# Altium schematic sheet [05] Charger.SchDoc("[05] Charger")
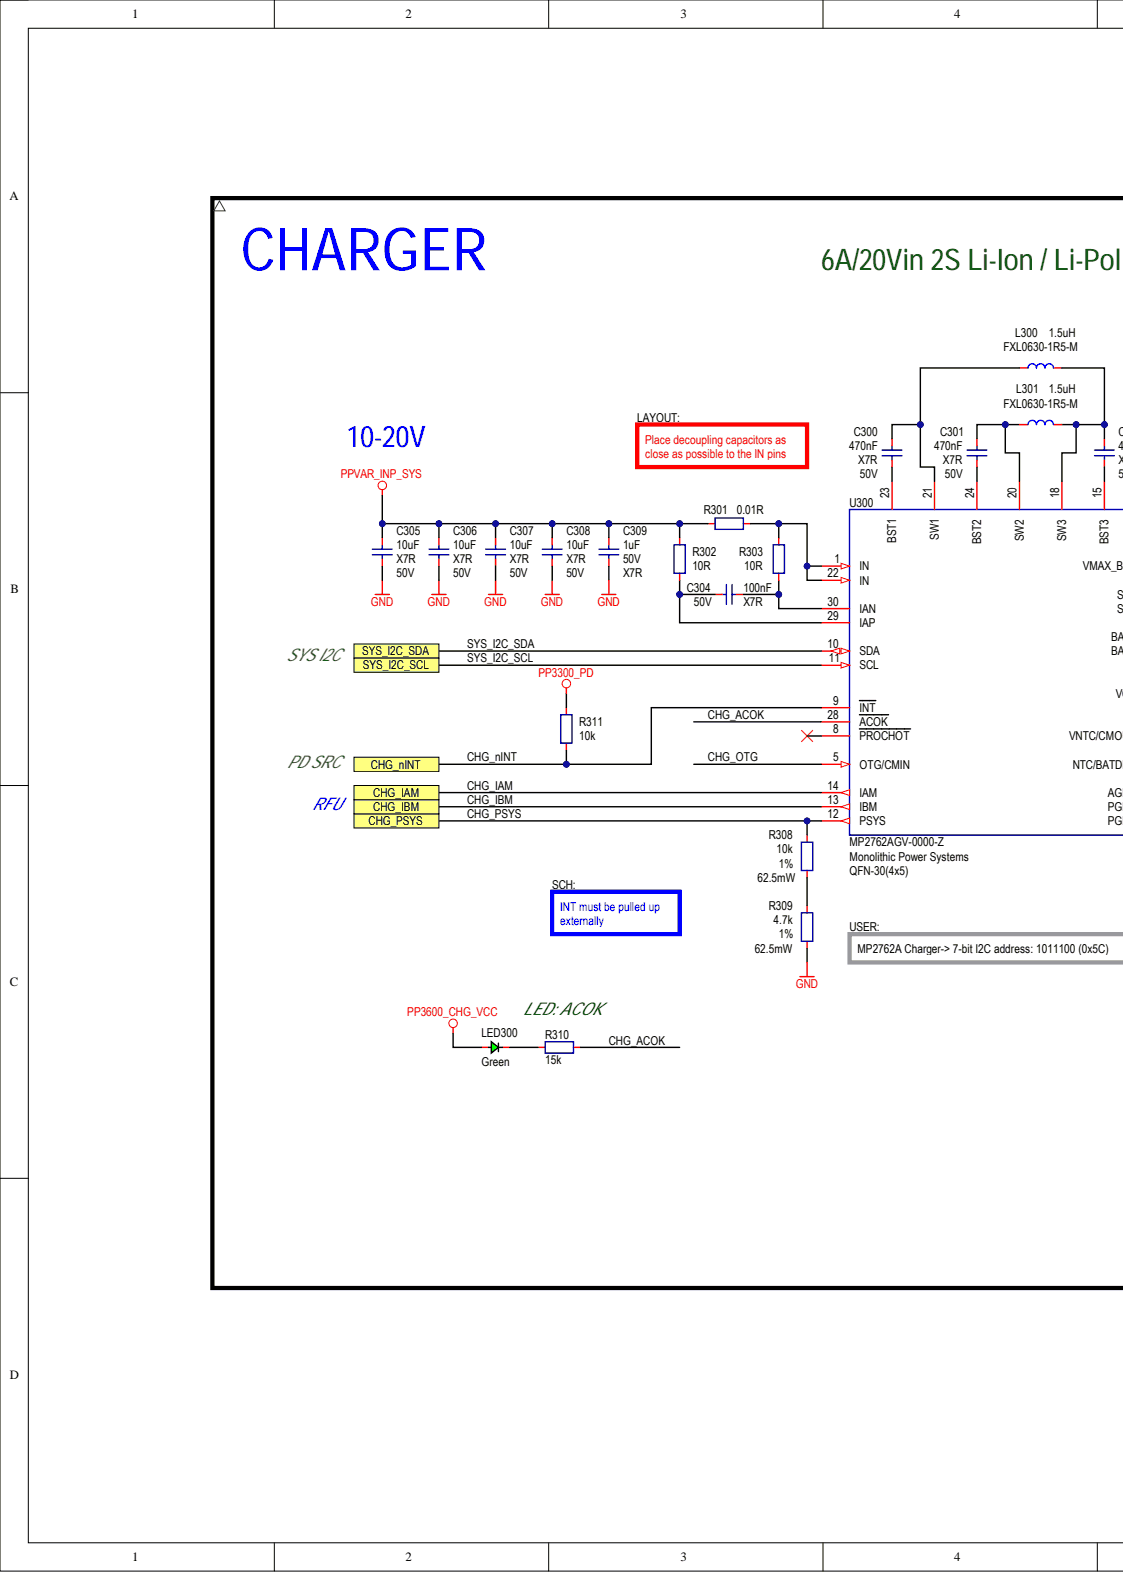
[diagram p0: [05] Charger.SchDoc, left]
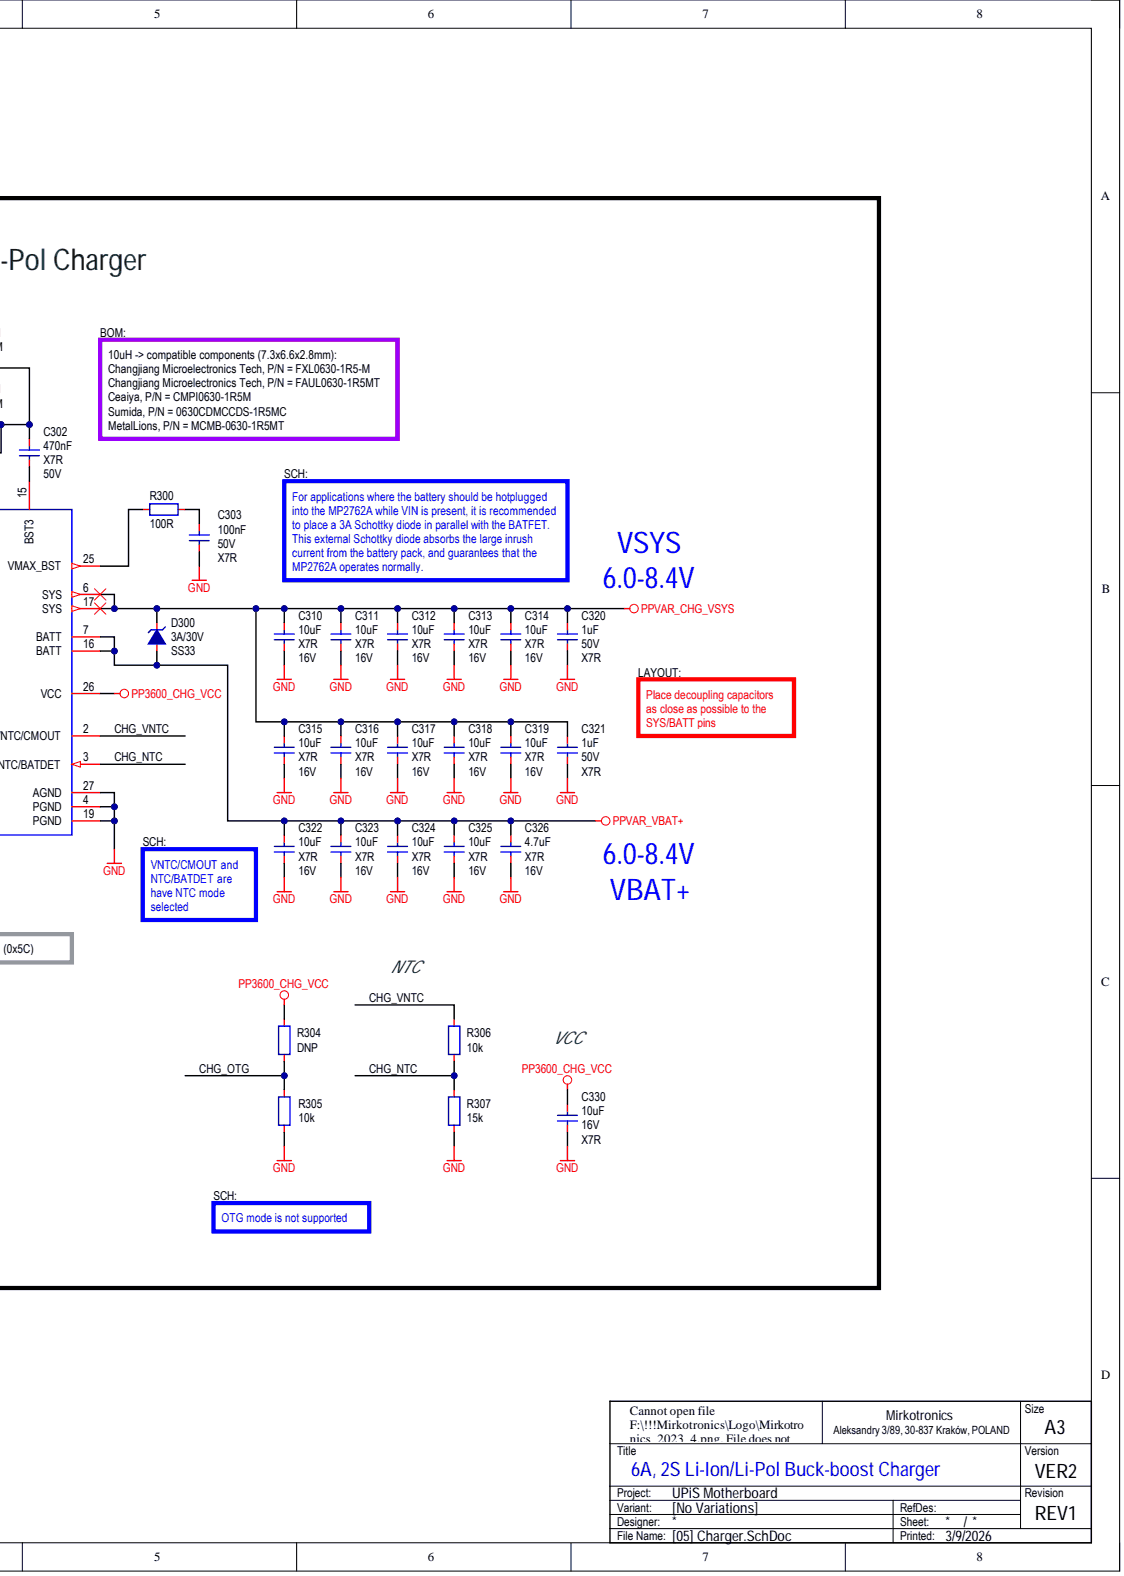
[diagram p1: [05] Charger.SchDoc, right]

## Parts
C300  470nF  Dielectric: X7R  Voltage Rating: 50V
C301  470nF  Dielectric: X7R  Voltage Rating: 50V
C302  470nF  Dielectric: X7R  Voltage Rating: 50V
C303  100nF  Dielectric: X7R  Voltage Rating: 50V
C304  100nF  Dielectric: X7R  Voltage Rating: 50V
C305  10uF  Dielectric: X7R  Voltage Rating: 50V
C306  10uF  Dielectric: X7R  Voltage Rating: 50V
C307  10uF  Dielectric: X7R  Voltage Rating: 50V
C308  10uF  Dielectric: X7R  Voltage Rating: 50V
C309  1uF  Dielectric: X7R  Voltage Rating: 50V
C310  10uF  Dielectric: X7R  Voltage Rating: 16V
C311  10uF  Dielectric: X7R  Voltage Rating: 16V
C312  10uF  Dielectric: X7R  Voltage Rating: 16V
C313  10uF  Dielectric: X7R  Voltage Rating: 16V
C314  10uF  Dielectric: X7R  Voltage Rating: 16V
C315  10uF  Dielectric: X7R  Voltage Rating: 16V
C316  10uF  Dielectric: X7R  Voltage Rating: 16V
C317  10uF  Dielectric: X7R  Voltage Rating: 16V
C318  10uF  Dielectric: X7R  Voltage Rating: 16V
C319  10uF  Dielectric: X7R  Voltage Rating: 16V
C320  1uF  Dielectric: X7R  Voltage Rating: 50V
C321  1uF  Dielectric: X7R  Voltage Rating: 50V
C322  10uF  Dielectric: X7R  Voltage Rating: 16V
C323  10uF  Dielectric: X7R  Voltage Rating: 16V
C324  10uF  Dielectric: X7R  Voltage Rating: 16V
C325  10uF  Dielectric: X7R  Voltage Rating: 16V
C326  4.7uF  Dielectric: X7R  Voltage Rating: 16V
C330  10uF  Dielectric: X7R  Voltage Rating: 16V
D300  3A/30V  MPN: SS33
L300  1.5uH  MPN: FXL0630-1R5-M
L301  1.5uH  MPN: FXL0630-1R5-M
LED300  Green
R300  100R
R301  0.01R
R302  10R
R303  10R
R304  DNP
R305  10k
R306  10k
R307  15k
R308  10k  Power Rating: 62.5mW  Tolerance: 1%
R309  4.7k  Power Rating: 62.5mW  Tolerance: 1%
R310  15k
R311  10k
U300  MP2762A  Manufacturer: Monolithic Power Systems  MPN: MP2762AGV-0000-Z  Package (Case): QFN-30(4x5)

## Ports
CHG_IAM, CHG_IBM, CHG_nINT, CHG_PSYS, SYS_I2C_SCL, SYS_I2C_SDA

## Nets
GND: C303.2 C305.1 C306.1 C307.1 C308.1 C309.1 C310.1 C311.1 C312.1 C313.1 C314.1 C315.1 C316.1 C317.1 C318.1 C319.1 C320.1 C321.1 C322.1 C323.1 C324.1 C325.1 C326.1 C330.1 R305.1 R307.2 R309.1 U300.4(PGND) U300.19(PGND) U300.27(AGND)
PP3300_PD: R311.2
PP3600_CHG_VCC: C330.2 LED300.2 R304.1 U300.26(VCC)
PPVAR_CHG_VSYS: C310.2 C311.2 C312.2 C313.2 C314.2 C315.2 C316.2 C317.2 C318.2 C319.2 C320.2 C321.2 D300.1 U300.6(SYS) U300.17(SYS)
PPVAR_INP_SYS: C305.2 C306.2 C307.2 C308.2 C309.2 R301.1 R302.1
PPVAR_VBAT+: C322.2 C323.2 C324.2 C325.2 C326.2 D300.2 U300.7(BATT) U300.16(BATT)
CHG_ACOK: R310.1 U300.28(ACOK)
CHG_IAM: U300.14(IAM) ; port CHG_IAM
CHG_IBM: U300.13(IBM) ; port CHG_IBM
CHG_nINT: R311.1 U300.9(INT) ; port CHG_nINT
CHG_NTC: R306.1 R307.1 U300.3(NTC/BATDET)
CHG_OTG: R304.2 R305.2 U300.5(OTG/CMIN)
CHG_PSYS: R308.2 U300.12(PSYS) ; port CHG_PSYS
CHG_VNTC: R306.2 U300.2(VNTC/CMOUT)
SYS_I2C_SCL: U300.11(SCL) ; port SYS_I2C_SCL
SYS_I2C_SDA: U300.10(SDA) ; port SYS_I2C_SDA
NetC300_1: C300.1 U300.23(BST1)
NetC300_2: C300.2 L300.1 U300.21(SW1)
NetC301_1: C301.1 U300.24(BST2)
NetC301_2: C301.2 L301.1 U300.20(SW2)
NetC302_1: C302.1 U300.15(BST3)
NetC302_2: C302.2 L300.2 L301.2 U300.18(SW3)
NetC303_1: C303.1 R300.2
NetC304_1: C304.1 R302.2 U300.29(IAP)
NetC304_2: C304.2 R303.2 U300.30(IAN)
NetLED300_1: LED300.1 R310.2
NetR300_1: R300.1 U300.25(VMAX_BST)
NetR301_2: R301.2 R303.1 U300.1(IN) U300.22(IN)
NetR308_1: R308.1 R309.2

Not connected: U300 8(PROCHOT)

## Library data of the parts
C300-C302 = CAP-0009: Capacitor; MLCC; 1uF; TBD; TBD; TBD; TBD; SMD; 0603 (1608);; Manufacturer: YAGEO; MPN: CC0603KRX7R9BB474; Database Table Name: CAPACITOR$; Capacitance: 470nF; Component Body: TBD; Component Height: TBD; Component Kind: Standard; Component Subtype: MLCC; Component Type: Capacitor; Features: TBD; ID: CAP-0009; Mounting Type: SMD; Operating Temperature: TBD; Package (Case): 0603 (1608); Packaging Type: TBD; ROHS Status: TBD; Supplier 1: LCSC; Supplier 2: Mouser; Supplier 3: DigiKey; Supplier Part Number 1: C513577; Tolerance: TBD; Value: 470nF; Library Name: MirFol_db_local.DbLib
C303 C304 = CAP-0004: Capacitor; MLCC; 100nF; 16VDC; X7R; 10%; Standard; SMD; 0402 (1005);; Manufacturer: Murata Electronics; MPN: GRM155R71H104KE14D; Component Body: 1.00 x 0.50mm; Database Table Name: CAPACITOR$; Packaging Type: Tape & Reel; Capacitance: 100nF; Component Height: 0.50mm; Component Kind: Standard; Component Subtype: MLCC; Component Type: Capacitor; Features: Standard; ID: CAP-0004; Mounting Type: SMD; Operating Temperature: -55°C to +125°C; Package (Case): 0402 (1005); ROHS Status: RoHS Compliant; Supplier 1: LCSC; Supplier 2: Mouser; Supplier 3: DigiKey; Supplier Part Number 1: C77020; Tolerance: 10%; Value: 100nF; Library Name: MirFol_db_local.DbLib
C305-C308 = CAP-0025: Capacitor; MLCC; GENERIC; TBD; TBD; TBD; TBD; SMD; 1206 (3216);; Manufacturer: Samsung Electro-Mechanics; MPN: CL31B106KBHNNNE; Database Table Name: CAPACITOR$; Tolerance: 10%; Capacitance: 10uF; Component Body: TBD; Component Height: TBD; Component Kind: Standard; Component Subtype: MLCC; Component Type: Capacitor; Features: TBD; ID: CAP-0016; Mounting Type: SMD; Operating Temperature: TBD; Package (Case): 1206 (3216); Packaging Type: TBD; ROHS Status: TBD; Supplier 1: LCSC; Supplier 2: Mouser; Supplier 3: DigiKey; Supplier Part Number 1: C89632; Value: 10uF; Library Name: MirFol_db_local.DbLib
C309 C320 C321 = CAP-0024: Capacitor; MLCC; GENERIC; TBD; TBD; TBD; TBD; SMD; 0805 (2012);; Manufacturer: Samsung Electro-Mechanics; MPN: CL21B105KBFNNNE; Database Table Name: CAPACITOR$; Tolerance: 10%; Capacitance: 1uF; Component Body: TBD; Component Height: TBD; Component Kind: Standard; Component Subtype: MLCC; Component Type: Capacitor; Features: TBD; ID: CAP-0024; Mounting Type: SMD; Operating Temperature: -55°C to 125°C; Package (Case): 0805 (2012); Packaging Type: TBD; ROHS Status: TBD; Supplier 1: LCSC; Supplier 2: Mouser; Supplier 3: Farnell; Supplier Part Number 1: C28323; Supplier Part Number 2: 187-CL21B105KBFNNNE; Supplier Part Number 3: 3013482; Value: 1uF; Library Name: MirFol_db_local.DbLib
C310-C319 C322-C325 C330 = CAP-0016: Capacitor; MLCC; 10uF; TBD; TBD; TBD; TBD; SMD; 0805 (2012);; Manufacturer: Samsung Electro-Mechanics; MPN: CL21B106KOQNNNE; Database Table Name: CAPACITOR$; Capacitance: 10uF; Component Body: TBD; Component Height: TBD; Component Kind: Standard; Component Subtype: MLCC; Component Type: Capacitor; Features: TBD; ID: CAP-0016; Mounting Type: SMD; Operating Temperature: TBD; Package (Case): 0805 (2012); Packaging Type: TBD; ROHS Status: TBD; Supplier 1: LCSC; Supplier 2: Mouser; Supplier 3: DigiKey; Supplier Part Number 1: C95841; Tolerance: TBD; Value: 10uF; Library Name: MirFol_db_local.DbLib
C326 = CAP-0023: Capacitor; MLCC; GENERIC; TBD; TBD; TBD; TBD; SMD; 0603 (1608);; Manufacturer: Murata Electronics; MPN: GRM188Z71C475KE21D; Component Body: 1.60 x 0.80mm; Database Table Name: CAPACITOR$; Packaging Type: Tape & Reel; Tolerance: 10%; Capacitance: 4.7uF; Component Height: 0.80mm; Component Kind: Standard; Component Subtype: MLCC; Component Type: Capacitor; Features: Standard; ID: CAP-0010; Mounting Type: SMD; Operating Temperature: -55°C to +125°C; Package (Case): 0603 (1608); ROHS Status: RoHS Compliant; Supplier 1: LCSC; Supplier 2: Mouser; Supplier 3: Digikey; Supplier Part Number 1: C389010; Value: 4.7uF; Library Name: MirFol_db_local.DbLib
D300 = DIO_TVS_UNI_KA: Diode; Schottky; Unipolar;; Manufacturer: GOODWORK; Component Subtype: Schottky diode; Package (Case): SMA (DO-214AC); Component Kind: Standard; Component Type: Diode; Mounting Type: SMD; Supplier 1: LCSC; Supplier 2: Mouser; Supplier 3: Digikey; Supplier Part Number 1: C41364476; Value: 3A/30V; Library Name: MirFol_lib.SchLib
L300 L301 = IND: Inductor;; Manufacturer: Changjiang Microelectronics Tech; Component Subtype: Power Inductor; Package (Case): SMD; Component Kind: Standard; Component Type: Inductor; Mounting Type: SMD; Supplier 1: LCSC; Supplier Part Number 1: C167217; Value: 1.5uH; Library Name: MirFol_lib.SchLib
LED300 = DIO_LED_GRN: Diode; LED; Green;; Manufacturer: Foshan NationStar Optoelectronics; MPN: NCD0805G1; Component Subtype: LED Diode; Package (Case): 0805 (2012); Component Kind: Standard; Component Type: LED; Mounting Type: SMD; Supplier: LCSC; Supplier 1: LCSC; Supplier Part Number 1: C84260; Value: Green; Library Name: MirFol_lib.SchLib
R300 = RES-0003: Resistor; Thick Film; 1k; 1%; 62.5mW; 100PPM; 50V; Standard; SMD; 0402 (1005);; Manufacturer: Yageo Corporation; MPN: RC0402FR-07100RL; Component Body: 1.00 x 0.50mm; Database Table Name: RESISTOR$; Packaging Type: Tape & Reel; Component Height: 0.35mm; Component Kind: Standard; Component Subtype: Thick Film; Component Type: Resistor; Features: Standard; ID: RES-0003; Mounting Type: SMD; Operating Temperature: -55°C to +155°C; Package (Case): 0402 (1005); Power Rating: 62.5mW; Resistance: 100R; ROHS Status: RoHS Compliant; Supplier 1: LCSC; Supplier 2: Mouser; Supplier 3: Digikey; Supplier Part Number 1: C106232; TCR: 100PPM; Tolerance: 1%; Value: 100R; Voltage Rating: 50V; Library Name: MirFol_db_local.DbLib
R301 = RES-0060: Resistor; Thick Film; GENERIC; TBD; TBD; TBD; TBD; TBD; SMD; 2512 (6432);; Manufacturer: Yageo; MPN: PE1206FKE470R01Z; Database Table Name: RESISTOR$; Package (Case): 1206 (3216); Packaging Type: Tape & Reel; Power Rating: 1W; TCR: 50PPM; Tolerance: 1%; Component Body: TBD; Component Height: TBD; Component Kind: Standard; Component Subtype: Thick Film; Component Type: Resistor; Features: TBD; ID: RES-0060; Mounting Type: SMD; Operating Temperature: TBD; Resistance: 0.01R; ROHS Status: RoHS Compliant; Supplier 1: LCSC; Supplier 2: Mouser; Supplier 3: Digikey; Supplier Part Number 1: C364375; Supplier Part Number 2: 603-1206FRE470R01Z1; Supplier Part Number 3: 13-PA1206FRE470R01ZTR-ND; Value: 0.01R; Voltage Rating: TBD; Library Name: MirFol_db_local.DbLib
R302 R303 = RES-0003: Resistor; Thick Film; 1k; 1%; 62.5mW; 100PPM; 50V; Standard; SMD; 0402 (1005);; Manufacturer: Yageo Corporation; MPN: RC0402FR-0710RL; Component Body: 1.00 x 0.50mm; Database Table Name: RESISTOR$; Packaging Type: Tape & Reel; Component Height: 0.35mm; Component Kind: Standard; Component Subtype: Thick Film; Component Type: Resistor; Features: Standard; ID: RES-0003; Mounting Type: SMD; Operating Temperature: -55°C to +155°C; Package (Case): 0402 (1005); Power Rating: 62.5mW; Resistance: 10R; ROHS Status: RoHS Compliant; Supplier 1: LCSC; Supplier 2: Mouser; Supplier 3: Digikey; Supplier Part Number 1: C138066; TCR: 100PPM; Tolerance: 1%; Value: 10R; Voltage Rating: 50V; Library Name: MirFol_db_local.DbLib
R304 = RES-0058: Resistor; Thick Film; GENERIC; TBD; TBD; TBD; TBD; TBD; SMD; 0402 (1005);; Component Body: 1.00 x 0.50mm; Database Table Name: RESISTOR$; Component Height: TBD; Component Kind: Standard; Component Subtype: Thick Film; Component Type: Resistor; Features: TBD; ID: RES-0058; Manufacturer: GENERIC; Mounting Type: SMD; MPN: DNP; Operating Temperature: TBD; Package (Case): 0402 (1005); Packaging Type: TBD; Power Rating: TBD; Resistance: DNP; ROHS Status: TBD; Supplier 1: LCSC; Supplier 2: Mouser; Supplier 3: Digikey; Supplier Part Number 1: DNP; Supplier Part Number 2: DNP; Supplier Part Number 3: DNP; TCR: TBD; Tolerance: TBD; Value: DNP; Voltage Rating: DNP; Library Name: MirFol_db_local.DbLib
R305 R306 R308 R311 = RES-0004: Resistor; Thick Film; 10k; 1%; 62.5mW; 100PPM; 50V; Standard; SMD; 0402 (1005);; Manufacturer: Yageo Corporation; MPN: RC0402FR-0710KL; Component Body: 1.00 x 0.50mm; Database Table Name: RESISTOR$; Packaging Type: Tape & Reel; Component Height: 0.35mm; Component Kind: Standard; Component Subtype: Thick Film; Component Type: Resistor; Features: Standard; ID: RES-0004; Mounting Type: SMD; Operating Temperature: -55°C to +155°C; Package (Case): 0402 (1005); Resistance: 10k; ROHS Status: RoHS Compliant; Supplier 1: LCSC; Supplier 2: Farnell; Supplier 3: Mouser; Supplier Part Number 1: C60490; Supplier Part Number 2: 9239359; Supplier Part Number 3: 603-RC0402FR-0710KL; TCR: 100PPM; Value: 10k; Voltage Rating: 50V; Library Name: MirFol_db_local.DbLib
  R305 R306 R311: Power Rating: 62.5mW; Tolerance: 1%
R307 R310 = RES-0058: Resistor; Thick Film; GENERIC; TBD; TBD; TBD; TBD; TBD; SMD; 0402 (1005);; Manufacturer: YAGEO; MPN: RC0402FR-0715KL; Component Body: 1.00 x 0.50mm; Database Table Name: RESISTOR$; Packaging Type: Tape & Reel; Power Rating: 62.5mW; TCR: 100PPM; Tolerance: 1%; Voltage Rating: 50V; Component Height: 0.35mm; Component Kind: Standard; Component Subtype: Thick Film; Component Type: Resistor; Features: Standard; ID: RES-0058; Mounting Type: SMD; Operating Temperature: -55°C to +155°C; Package (Case): 0402 (1005); Resistance: 15k; ROHS Status: RoHS Compliant; Supplier 1: LCSC; Supplier 2: Mouser; Supplier 3: Digikey; Supplier Part Number 1: C114761; Supplier Part Number 2: 603-RC0402FR-0715KL; Supplier Part Number 3: 311-15.0KLRTR-ND; Value: 15k; Library Name: MirFol_db_local.DbLib
R309 = RES-0058: Resistor; Thick Film; GENERIC; TBD; TBD; TBD; TBD; TBD; SMD; 0402 (1005);; Manufacturer: YAGEO; MPN: RC0402FR-074K7L; Component Body: 1.00 x 0.50mm; Database Table Name: RESISTOR$; Packaging Type: Tape & Reel; TCR: 100PPM; Voltage Rating: 50V; Component Height: 0.35mm; Component Kind: Standard; Component Subtype: Thick Film; Component Type: Resistor; Features: Standard; ID: RES-0058; Mounting Type: SMD; Operating Temperature: -55°C to +155°C; Package (Case): 0402 (1005); Resistance: 4.7k; ROHS Status: RoHS Compliant; Supplier 1: LCSC; Supplier 2: Mouser; Supplier 3: Digikey; Supplier Part Number 1: C105871; Supplier Part Number 2: 603-RC0402FR-075K1L; Supplier Part Number 3: 311-5.10KLRTR-ND; Value: 4.7k; Library Name: MirFol_db_local.DbLib
U300 = ICC_MPS_MP2762A_QFN30: IC; Battery charger;; Component Kind: Standard; Component Subtype: Battery charger; Component Type: IC; Mounting Type: SMD; Supplier 1: LCSC; Supplier Part Number 1: C26697965; Value: MP2762A; Library Name: Pineberry_Lib.SchLib
